# Altium schematic sheet CTRres.SchDoc
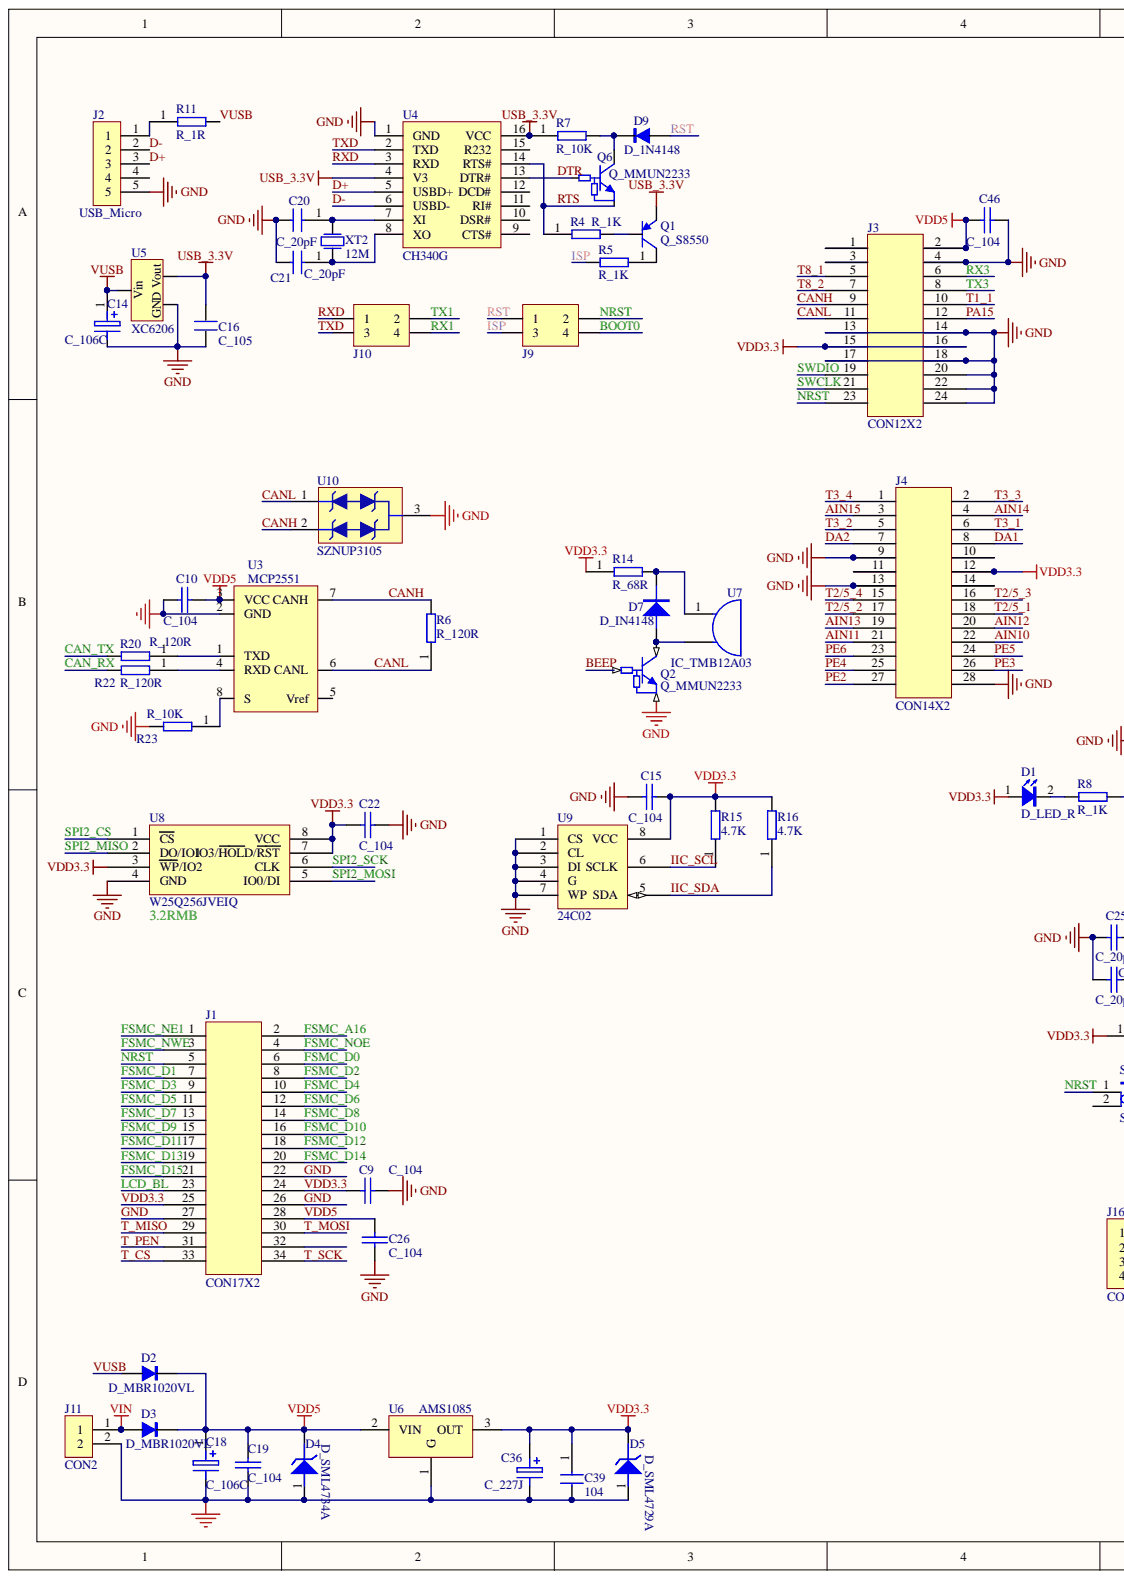
[diagram p0: CTRres.SchDoc, left]
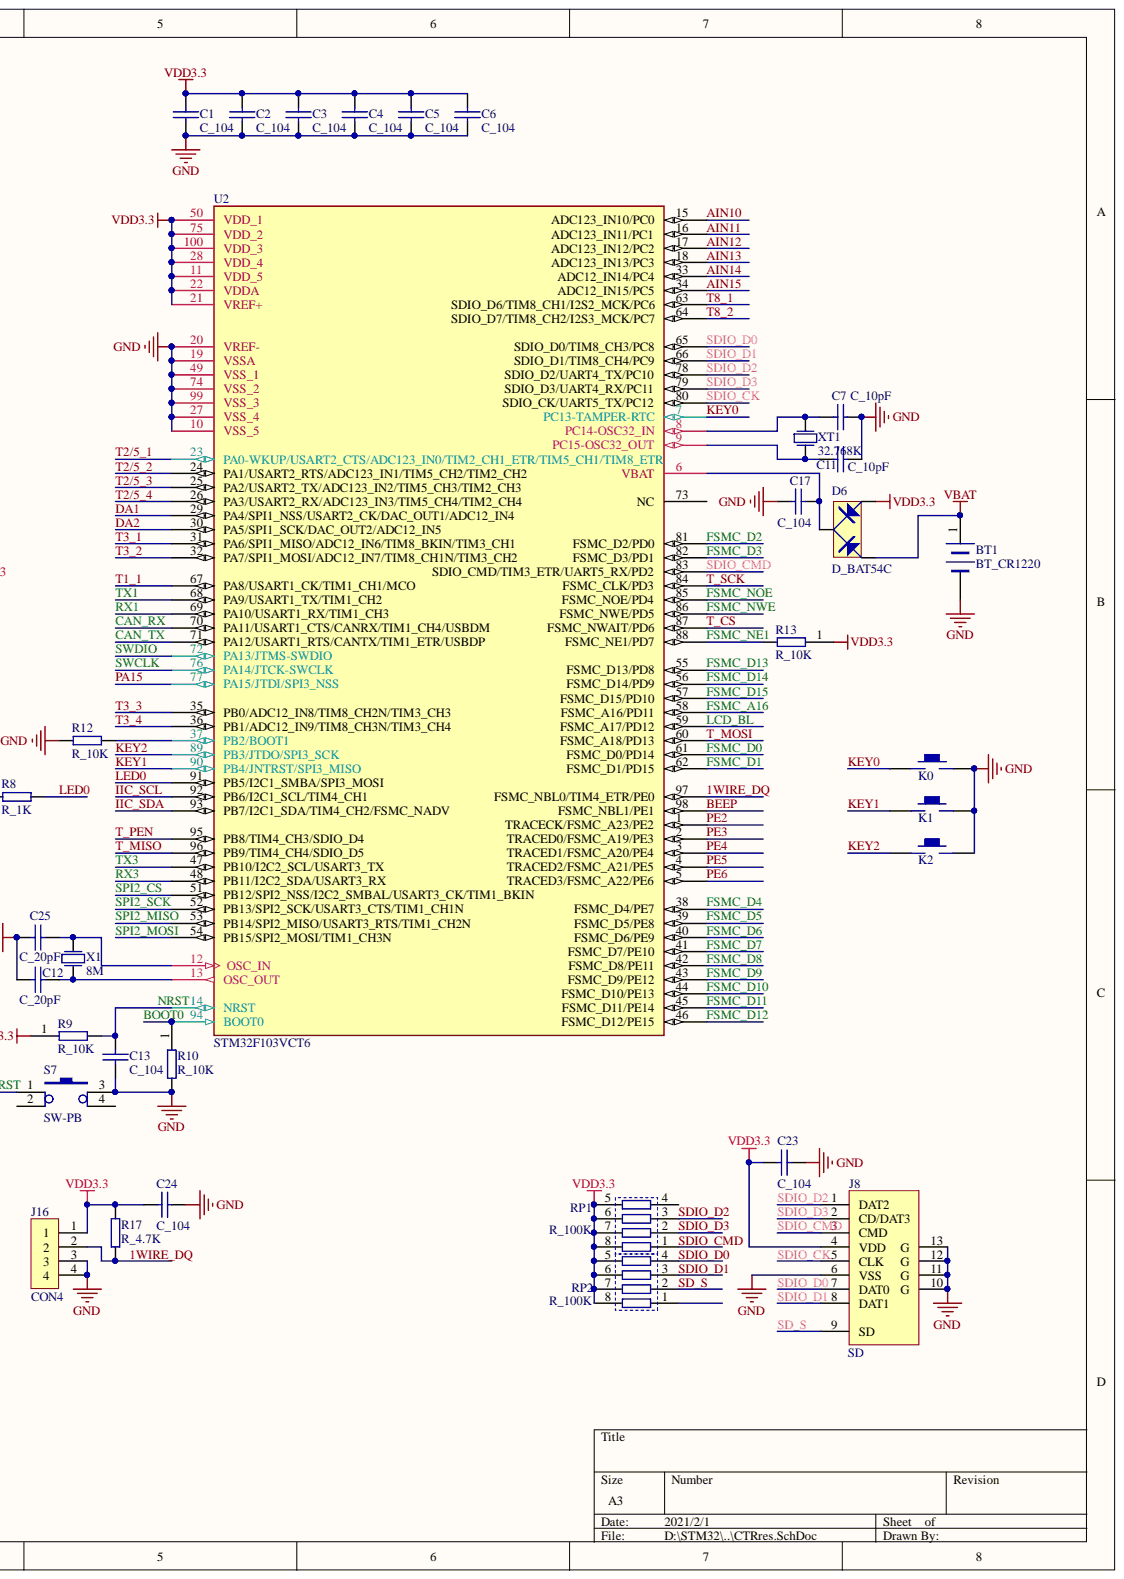
[diagram p1: CTRres.SchDoc, right]

## Parts
BT1  BT_CR1220  [CR1220_SMT]
C1  C_104  [0603C]
C2  C_104  [0603C]
C3  C_104  [0603C]
C4  C_104  [0603C]
C5  C_104  [0603C]
C6  C_104  [0603C]
C7  C_10pF  [0603C]
C9  C_104  [0603C]
C10  C_104  [0603C]
C11  C_10pF  [0603C]
C12  C_20pF  [0603C]
C13  C_104  [0603C]
C14  C_106C  [3216TC]
C15  C_104  [0603C]
C16  C_105  [0603C]
C17  C_104  [0603C]
C18  C_106C  [3216TC]
C19  C_104  [0603C]
C20  C_20pF  [0603C]
C21  C_20pF  [0603C]
C22  C_104  [0603C]
C23  C_104  [0603C]
C24  C_104  [0603C]
C25  C_20pF  [0603C]
C26  C_104  [0603C]
C36  C_227J  [3528TC]
C39  104  [0603C]
C46  C_104  [0603C]
D1  D_LED_R  [LED-0805]
D2  D_MBR1020VL  [SOD-123]
D3  D_MBR1020VL  [SOD-123]
D4  D_SML4734A  [DO-214AC]
D5  D_SML4729A  [DO-214AC]
D6  D_BAT54C  [SOT-23]
D7  D_IN4148  [SOD-123]
D9  D_1N4148  [SOD-123]
J1  CON17X2  [IDC34]
J2  USB_Micro  [USB-MICRO]
J3  CON12X2  [IDC24]
J4  CON14X2  [IDC28]
J8  SD  [SD_TF]
J9  Header2X2  [HDR-2X2]  (value not drawn)
J10  Header2X2  [HDR-2X2]  (value not drawn)
J11  CON2  [XH-2A]
J16  CON4  [SIP4-2.54]
K0  KEY_M  [SMD3X6]  (value not drawn)
K1  KEY_M  [SMD3X6]  (value not drawn)
K2  KEY_M  [SMD3X6]  (value not drawn)
Q1  Q_S8550  [SOT-23]
Q2  Q_MMUN2233  [SOT-23]
Q6  Q_MMUN2233  [SOT-23]
R4  R_1K  [0603R]
R5  R_1K  [0603R]
R6  R_120R  [0603R]
R7  R_10K  [0603R]
R8  R_1K  [0603R]
R9  R_10K  [0603R]
R10  R_10K  [0603R]
R11  R_1R  [0805C]
R12  R_10K  [0603R]
R13  R_10K  [0603R]
R14  R_68R  [0805]
R15  4.7K  [0603R]
R16  4.7K  [0603R]
R17  R_4.7K  [0603R]
R20  R_120R  [0603R]
R22  R_120R  [0603R]
R23  R_10K  [0603R]
RP1  R_100K  [RCA03-4D]
RP2  R_100K  [RCA03-4D]
S7  SW-PB  [KEY_DIP]
U2  STM32F103VCT6  [LQFP100]
U3  MCP2551  [SO-8]
U4  CH340G  [SO-16]
U5  XC6206  [SOT-23]
U6  AMS1085  [TO-252AA]
U7  IC_TMB12A03  [BUZZER]
U8  W25Q256JVEIQ  [W25QXX_SOP8]
U9  24C02  [SO-8]
U10  SZNUP3105  [SOT-23]
X1  8M  [XTAL-49S DIP]
XT1  32.768K  [XTAL3X8w]
XT2  12M  [5032]

## Nets
USB_3.3V: C16.2 Q1.2 R7.1 U4.4(V3) U4.16(VCC) U5.2
VBAT: BT1.1 D6.1
VIN: D3.1 J11.1
1WIRE_DQ: J16.2 R17.1 U2.97(FSMC_NBL0/TIM4_ETR/PE0)
AIN10: J4.22 U2.15(ADC123_IN10/PC0)
AIN11: J4.21 U2.16(ADC123_IN11/PC1)
AIN12: J4.20 U2.17(ADC123_IN12/PC2)
AIN13: J4.19 U2.18(ADC123_IN13/PC3)
AIN14: J4.4 U2.33(ADC12_IN14/PC4)
AIN15: J4.3 U2.34(ADC12_IN15/PC5)
BEEP: Q2.1 U2.98(FSMC_NBL1/PE1)
BOOT0: J9.4 R10.1 U2.94(BOOT0)
CAN_RX: R22.2 U2.70(PA11/USART1_CTS/CANRX/TIM1_CH4/USBDM)
CAN_TX: R20.2 U2.71(PA12/USART1_RTS/CANTX/TIM1_ETR/USBDP)
CANH: J3.9 R6.2 U3.7(CANH) U10.2
CANL: J3.11 R6.1 U3.6(CANL) U10.1
D+: J2.3 U4.5(USBD+)
D-: J2.2 U4.6(USBD-)
DA1: J4.8 U2.29(PA4/SPI1_NSS/USART2_CK/DAC_OUT1/ADC12_IN4)
DA2: J4.7 U2.30(PA5/SPI1_SCK/DAC_OUT2/ADC12_IN5)
DTR: Q6.1 U4.13(DTR#)
FSMC_A16: J1.2 U2.58(FSMC_A16/PD11)
FSMC_D0: J1.6 U2.61(FSMC_D0/PD14)
FSMC_D1: J1.7 U2.62(FSMC_D1/PD15)
FSMC_D2: J1.8 U2.81(FSMC_D2/PD0)
FSMC_D3: J1.9 U2.82(FSMC_D3/PD1)
FSMC_D4: J1.10 U2.38(FSMC_D4/PE7)
FSMC_D5: J1.11 U2.39(FSMC_D5/PE8)
FSMC_D6: J1.12 U2.40(FSMC_D6/PE9)
FSMC_D7: J1.13 U2.41(FSMC_D7/PE10)
FSMC_D8: J1.14 U2.42(FSMC_D8/PE11)
FSMC_D9: J1.15 U2.43(FSMC_D9/PE12)
FSMC_D10: J1.16 U2.44(FSMC_D10/PE13)
FSMC_D11: J1.17 U2.45(FSMC_D11/PE14)
FSMC_D12: J1.18 U2.46(FSMC_D12/PE15)
FSMC_D13: J1.19 U2.55(FSMC_D13/PD8)
FSMC_D14: J1.20 U2.56(FSMC_D14/PD9)
FSMC_D15: J1.21 U2.57(FSMC_D15/PD10)
FSMC_NE1: J1.1 R13.2 U2.88(FSMC_NE1/PD7)
FSMC_NOE: J1.4 U2.85(FSMC_NOE/PD4)
FSMC_NWE: J1.3 U2.86(FSMC_NWE/PD5)
GND: BT1.2 C1.1 C2.1 C3.1 C4.1 C5.1 C6.1 C7.1 C9.1 C10.1 C11.1 C12.2 C13.1 C14.2 C15.1 C16.1 C17.1 C18.2 C19.2 C20.2 C21.2 C22.2 C23.1 C24.2 C25.2 C26.2 C36.2 C39.2 C46.1 D4.1 D5.1 J1.22 J1.26 J1.27 J2.5 J3.3 J3.4 J3.13 J3.14 J3.17 J3.18 J3.20 J3.22 J3.24 J4.9 J4.10 J4.13 J4.14 J4.28 J8.6(VSS) J8.10(G) J8.11(G) J8.12(G) J8.13(G) J11.2 J16.3 J16.4 K0.2 K1.2 K2.2 Q2.2 R10.2 R12.2 R23.2 S7.3 U2.10(VSS_5) U2.19(VSSA) U2.20(VREF-) U2.27(VSS_4) U2.49(VSS_1) U2.74(VSS_2) U2.99(VSS_3) U3.2(GND) U4.1(GND) U5.1 U6.1 U8.4(GND) U9.1(CS) U9.2(CL) U9.3(DI) U9.4(G) U9.7(WP) U10.3
IIC_SCL: R15.1 U2.92(PB6/I2C1_SCL/TIM4_CH1) U9.6(SCLK)
IIC_SDA: R16.1 U2.93(PB7/I2C1_SDA/TIM4_CH2/FSMC_NADV) U9.5(SDA)
ISP: J9.3 R5.2
KEY0: K0.1 U2.7(PC13-TAMPER-RTC)
KEY1: K1.1 U2.90(PB4/JNTRST/SPI3_MISO)
KEY2: K2.1 U2.89(PB3/JTDO/SPI3_SCK)
LCD_BL: J1.23 U2.59(FSMC_A17/PD12)
LED0: R8.1 U2.91(PB5/I2C1_SMBA/SPI3_MOSI)
NRST: C13.2 J1.5 J3.23 J9.2 R9.2 S7.1 U2.14(NRST)
PA15: J3.12 U2.77(PA15/JTDI/SPI3_NSS)
PE2: J4.27 U2.1(TRACECK/FSMC_A23/PE2)
PE3: J4.26 U2.2(TRACED0/FSMC_A19/PE3)
PE4: J4.25 U2.3(TRACED1/FSMC_A20/PE4)
PE5: J4.24 U2.4(TRACED2/FSMC_A21/PE5)
PE6: J4.23 U2.5(TRACED3/FSMC_A22/PE6)
RST: D9.1 J9.1
RTS: Q6.2 R4.1 U4.14(RTS#)
RX1: J10.4 U2.69(PA10/USART1_RX/TIM1_CH3)
RX3: J3.6 U2.48(PB11/I2C2_SDA/USART3_RX)
RXD: J10.1 U4.3(RXD)
SD_S: J8.9 RP2.2
SDIO_CK: J8.5(CLK) U2.80(SDIO_CK/UART5_TX/PC12)
SDIO_CMD: J8.3(CMD) RP1.1 U2.83(SDIO_CMD/TIM3_ETR/UART5_RX/PD2)
SDIO_D0: J8.7(DAT0) RP2.4 U2.65(SDIO_D0/TIM8_CH3/PC8)
SDIO_D1: J8.8(DAT1) RP2.3 U2.66(SDIO_D1/TIM8_CH4/PC9)
SDIO_D2: J8.1(DAT2) RP1.3 U2.78(SDIO_D2/UART4_TX/PC10)
SDIO_D3: J8.2(CD/DAT3) RP1.2 U2.79(SDIO_D3/UART4_RX/PC11)
SPI2_CS: U2.51(PB12/SPI2_NSS/I2C2_SMBAL/USART3_CK/TIM1_BKIN) U8.1(CS)
SPI2_MISO: U2.53(PB14/SPI2_MISO/USART3_RTS/TIM1_CH2N) U8.2(DO/IO1)
SPI2_MOSI: U2.54(PB15/SPI2_MOSI/TIM1_CH3N) U8.5(IO0/DI)
SPI2_SCK: U2.52(PB13/SPI2_SCK/USART3_CTS/TIM1_CH1N) U8.6(CLK)
SWCLK: J3.21 U2.76(PA14/JTCK-SWCLK)
SWDIO: J3.19 U2.72(PA13/JTMS-SWDIO)
T1_1: J3.10 U2.67(PA8/USART1_CK/TIM1_CH1/MCO)
T2/5_1: J4.18 U2.23(PA0-WKUP/USART2_CTS/ADC123_IN0/TIM2_CH1_ETR/TIM5_CH1/TIM8_ETR)
T2/5_2: J4.17 U2.24(PA1/USART2_RTS/ADC123_IN1/TIM5_CH2/TIM2_CH2)
T2/5_3: J4.16 U2.25(PA2/USART2_TX/ADC123_IN2/TIM5_CH3/TIM2_CH3)
T2/5_4: J4.15 U2.26(PA3/USART2_RX/ADC123_IN3/TIM5_CH4/TIM2_CH4)
T3_1: J4.6 U2.31(PA6/SPI1_MISO/ADC12_IN6/TIM8_BKIN/TIM3_CH1)
T3_2: J4.5 U2.32(PA7/SPI1_MOSI/ADC12_IN7/TIM8_CH1N/TIM3_CH2)
T3_3: J4.2 U2.35(PB0/ADC12_IN8/TIM8_CH2N/TIM3_CH3)
T3_4: J4.1 U2.36(PB1/ADC12_IN9/TIM8_CH3N/TIM3_CH4)
T8_1: J3.5 U2.63(SDIO_D6/TIM8_CH1/I2S2_MCK/PC6)
T8_2: J3.7 U2.64(SDIO_D7/TIM8_CH2/I2S3_MCK/PC7)
T_CS: J1.33 U2.87(FSMC_NWAIT/PD6)
T_MISO: J1.29 U2.96(PB9/TIM4_CH4/SDIO_D5)
T_MOSI: J1.30 U2.60(FSMC_A18/PD13)
T_PEN: J1.31 U2.95(PB8/TIM4_CH3/SDIO_D4)
T_SCK: J1.34 U2.84(FSMC_CLK/PD3)
TX1: J10.2 U2.68(PA9/USART1_TX/TIM1_CH2)
TX3: J3.8 U2.47(PB10/I2C2_SCL/USART3_TX)
TXD: J10.3 U4.2(TXD)
VDD3.3: C1.2 C2.2 C3.2 C4.2 C5.2 C6.2 C9.2 C15.2 C22.1 C23.2 C24.1 C36.1 C39.1 D1.1 D5.2 D6.2 J1.24 J1.25 J3.15 J3.16 J4.11 J4.12 J8.4(VDD) J16.1 R9.1 R13.1 R14.1 R15.2 R16.2 R17.2 RP1.5 RP1.6 RP1.7 RP1.8 RP2.5 RP2.6 RP2.7 RP2.8 U2.11(VDD_5) U2.21(VREF+) U2.22(VDDA) U2.28(VDD_4) U2.50(VDD_1) U2.75(VDD_2) U2.100(VDD_3) U6.3 U8.3(WP/IO2) U8.7(IO3/HOLD/RST) U8.8(VCC) U9.8(VCC)
VDD5: C10.2 C18.1 C19.1 C26.1 C46.2 D2.2 D3.2 D4.2 J1.28 J3.1 J3.2 U3.3(VCC) U6.2
VUSB: C14.1 D2.1 R11.2 U5.3
NetC7_2: C7.2 U2.8(PC14-OSC32_IN) XT1.2
NetC11_2: C11.2 U2.9(PC15-OSC32_OUT) XT1.1
NetC12_1: C12.1 U2.13(OSC_OUT) X1.1
NetC17_2: C17.2 D6.3 U2.6(VBAT)
NetC20_1: C20.1 U4.7(XI) XT2.2
NetC21_1: C21.1 U4.8(XO) XT2.1
NetC25_1: C25.1 U2.12(OSC_IN) X1.2
NetD1_2: D1.2 R8.2
NetD7_1: D7.1 Q2.3 U7.2
NetD7_2: D7.2 R14.2 U7.1
NetD9_2: D9.2 Q6.3 R7.2
NetJ2_1: J2.1 R11.1
NetQ1_1: Q1.1 R4.2
NetQ1_3: Q1.3 R5.1
NetR12_1: R12.1 U2.37(PB2/BOOT1)
NetR20_1: R20.1 U3.1(TXD)
NetR22_1: R22.1 U3.4(RXD)
NetR23_1: R23.1 U3.8(S)

Not connected: J1 32; J2 4; RP1 4; RP2 1; S7 2 4; U2 73(NC); U3 5(Vref); U4 9(CTS#) 10(DSR#) 11(RI#) 12(DCD#) 15(R232)

## Library data of the parts
BT1 = BATTERY: Component Kind: Standard; Description: Battery; Library Name: SCH-杂元件库.SCHLIB
C1-C7 C9-C13 C15 C17 C19 C20 C22-C26 C39 C46 = CAP: Capacitor; Component Kind: Standard
  C39: Value: 104
  C20 C39: Library Name: SCH-模拟器件.SCHLIB
C14 C18 = CAP2: Bi-Polar Capacitor; Component Kind: Standard; Simulation: CAP
C16 = C: Component Kind: Standard; Library Name: IMX6UL_ALPHA(底板)_V2.0.IntLib
C21 = CAP: Capacitor; Value: 20P; Component Kind: Standard; Library Name: SCH-模拟器件.SCHLIB
C36 = WELL.SCH_47u/35V_21: Component Kind: Standard
D1 = LED: Component Kind: Standard
D2 D3 D9 = 1N4148: Component Kind: Standard; Library Name: machong.SchLib
D4 D5 = ZENER3: Zener Diode; Component Kind: Standard
D6 = BAT54C: Component Kind: Standard; Library Name: SCH-模拟器件.SCHLIB
D7 = DIODE: Component Kind: Standard
J1 = CON16X2: Component Kind: Standard; Library Name: machong.SchLib
J2 = CON5: Component Kind: Standard; Library Name: machong.SchLib
J8 = SD-TF: Component Kind: Standard; Library Name: SCH-杂元件库.SCHLIB
J9 J10 = Header 2X2: 2*2P接插件; 版权归属: 源创客; BOM名称: 2*2P接插件; Component Kind: Standard; Library Name: IMX6UL_ALPHA(底板)_V2.0.IntLib; 学习资源: www.ooocke.com
Q1 = PNP: Component Kind: Standard; Library Name: machong.SchLib
Q2 = NPN1: Component Kind: Standard
Q6 = N: Component Kind: Standard; Library Name: SCH-模拟器件.SCHLIB
R4 R5 R7 R9-R11 = RRR: Value: 10K; Component Kind: Standard; Library Name: SCH-模拟器件.SCHLIB
R6 R13-R16 R20 R22 R23 = RRR: Component Kind: Standard
  R6 R20 R22: Value: R_120R
  R15 R16: Value: 4.7K; Library Name: 模拟器件.SCHLIB
  R6 R20 R22 R23: Library Name: SCH-模拟器件.SCHLIB
  R14: Library Name: machong.pcblib
R8 R12 R17 = R: Component Kind: Standard
RP1 RP2 = RESP4: value: 100K; Component Kind: Standard; Library Name: SCH-模拟器件.SCHLIB
S7 = SW: Component Kind: Standard; Library Name: SCH-杂元件库.SCHLIB
U3 = CAN: Component Kind: Standard
U5 = LDO XC6206: LDO; Component Kind: Standard; ComponentLink1Description: 供应商链接; ComponentLink1URL: http://www.szlcsc.com/product/details_5896.html; Library Name: IMX6UL_ALPHA(底板)_V2.0.IntLib; Package: SOT-23; Supplier: LC; SuppliersPartNumber: C5446
U7 = BUZZER: Component Kind: Standard; Description: Buzzer; Library Name: SCH-杂元件库.SCHLIB
U8 = W25Q128FV: Component Kind: Standard; Library Name: machong.SchLib
U9 = 24C64: Component Kind: Standard; Library Name: SCH-SAVE.SCHLIB
X1 XT1 XT2 = XTAL: CRYSTAL; Component Kind: Standard; Library Name: SCH-模拟器件.SCHLIB
J3 = CON12X2: Component Kind: Standard; Library Name: machong.SchLib
J4 = CON14X2: Component Kind: Standard; Library Name: machong.SchLib
J11 = CON2: Component Kind: Standard; Library Name: machong.SchLib
J16 = CON4: Component Kind: Standard; Library Name: machong.SchLib
K0-K2 = KEY_M: Component Kind: Standard; Library Name: WarShip V3 STM32103封装库.IntLib
U2 = STM32F103VCT6: Component Kind: Standard; Library Name: machong.SchLib
U4 = CH340G: Component Kind: Standard; Library Name: machong.SchLib
U6 = AMS1085: Component Kind: Standard; Library Name: SCH-POWER.SCHLIB
U10 = SZNUP3105: Component Kind: Standard; Library Name: machong.SchLib
